# Altium schematic sheet Page H_B2B_A.SchDoc
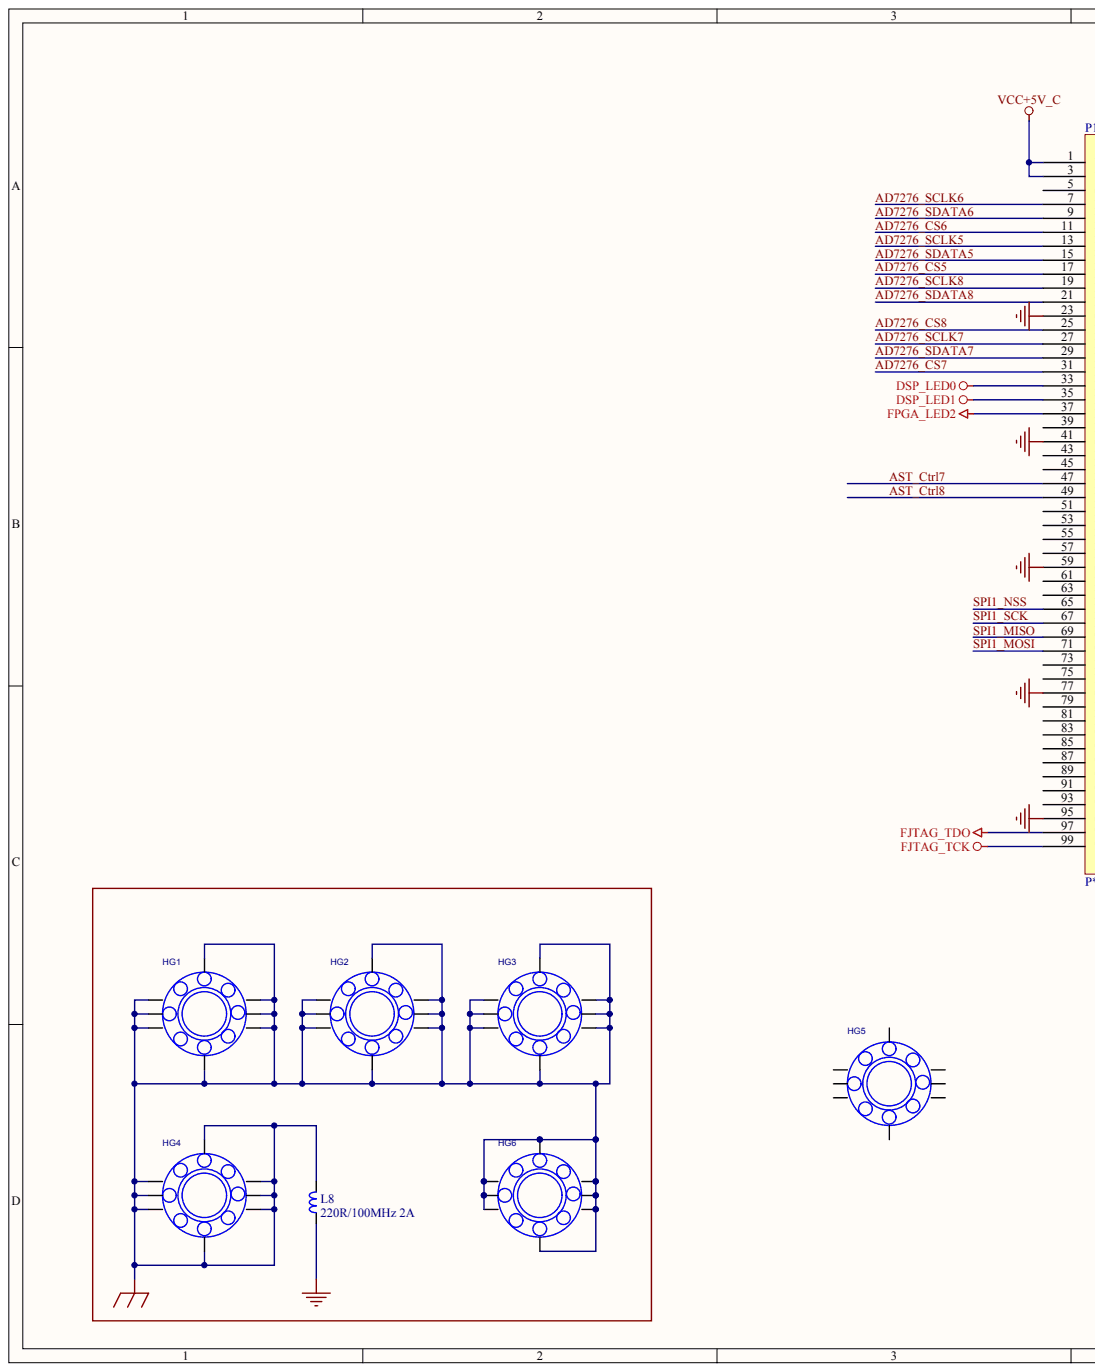
[diagram p0: Page H_B2B_A.SchDoc, left]
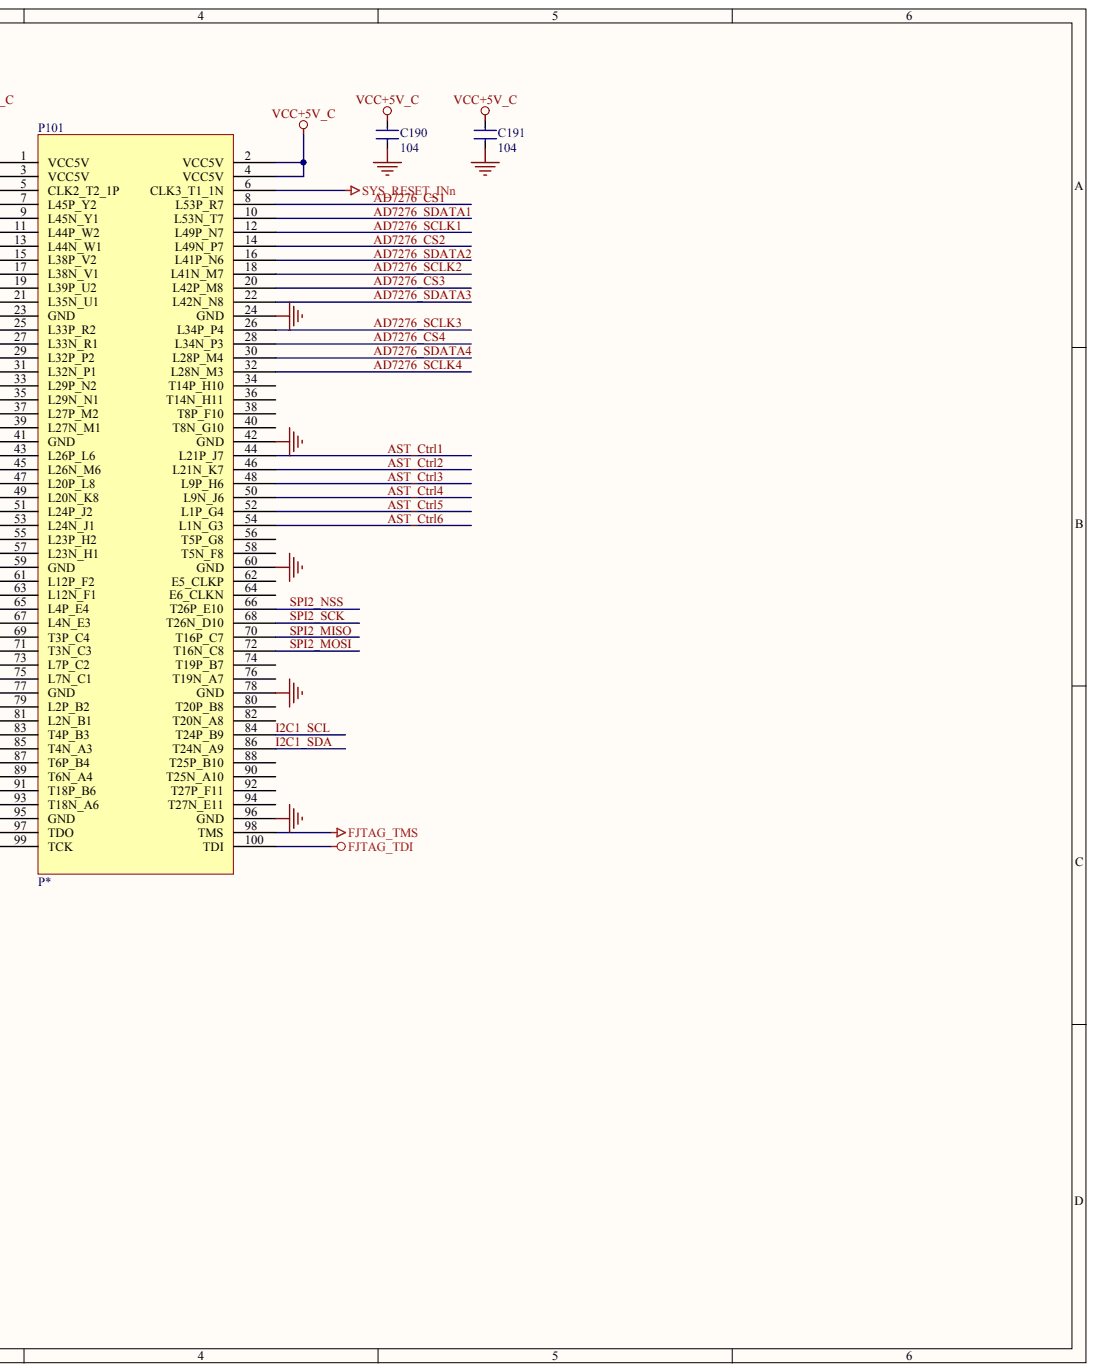
[diagram p1: Page H_B2B_A.SchDoc, right]

## Parts
C190  104
C191  104
HG1  MOUNTING_HOLE_NL  (value not drawn)
HG2  MOUNTING_HOLE_NL  (value not drawn)
HG3  MOUNTING_HOLE_NL  (value not drawn)
HG4  MOUNTING_HOLE_NL  (value not drawn)
HG5  MOUNTING_HOLE_NL  (value not drawn)
HG6  MOUNTING_HOLE_NL  (value not drawn)
L8  220R/100MHz 2A
P101  P*

## Nets
DSP_LED0: P101.33(L29P_N2)
DSP_LED1: P101.35(L29N_N1)
FJTAG_TCK: P101.99(TCK)
FJTAG_TDI: P101.100(TDI)
FJTAG_TDO: P101.97(TDO)
FJTAG_TMS: P101.98(TMS)
FPGA_LED2: P101.37(L27P_M2)
GND: C190.1 C191.1 L8.1 P101.23(GND) P101.24(GND) P101.41(GND) P101.42(GND) P101.59(GND) P101.60(GND) P101.77(GND) P101.78(GND) P101.95(GND) P101.96(GND)
GND_EARTH: HG1.1 HG1.2 HG1.3 HG1.4 HG1.5 HG1.6 HG1.7 HG1.8 HG2.1 HG2.2 HG2.3 HG2.4 HG2.5 HG2.6 HG2.7 HG2.8 HG3.1 HG3.2 HG3.3 HG3.4 HG3.5 HG3.6 HG3.7 HG3.8 HG4.1 HG4.2 HG4.3 HG4.4 HG4.5 HG4.6 HG4.7 HG4.8 HG6.1 HG6.2 HG6.3 HG6.4 HG6.5 HG6.6 HG6.7 HG6.8 L8.2
SYS_RESET_INN: P101.6(CLK3_T1_1N)
VCC+5V_C: C190.2 C191.2 P101.1(VCC5V) P101.2(VCC5V) P101.3(VCC5V) P101.4(VCC5V)
AD7276_CS1: P101.8(L53P_R7)
AD7276_CS2: P101.14(L49N_P7)
AD7276_CS3: P101.20(L42P_M8)
AD7276_CS4: P101.28(L34N_P3)
AD7276_CS5: P101.17(L38N_V1)
AD7276_CS6: P101.11(L44P_W2)
AD7276_CS7: P101.31(L32N_P1)
AD7276_CS8: P101.25(L33P_R2)
AD7276_SCLK1: P101.12(L49P_N7)
AD7276_SCLK2: P101.18(L41N_M7)
AD7276_SCLK3: P101.26(L34P_P4)
AD7276_SCLK4: P101.32(L28N_M3)
AD7276_SCLK5: P101.13(L44N_W1)
AD7276_SCLK6: P101.7(L45P_Y2)
AD7276_SCLK7: P101.27(L33N_R1)
AD7276_SCLK8: P101.19(L39P_U2)
AD7276_SDATA1: P101.10(L53N_T7)
AD7276_SDATA2: P101.16(L41P_N6)
AD7276_SDATA3: P101.22(L42N_N8)
AD7276_SDATA4: P101.30(L28P_M4)
AD7276_SDATA5: P101.15(L38P_V2)
AD7276_SDATA6: P101.9(L45N_Y1)
AD7276_SDATA7: P101.29(L32P_P2)
AD7276_SDATA8: P101.21(L35N_U1)
AST_CTRL1: P101.44(L21P_J7)
AST_CTRL2: P101.46(L21N_K7)
AST_CTRL3: P101.48(L9P_H6)
AST_CTRL4: P101.50(L9N_J6)
AST_CTRL5: P101.52(L1P_G4)
AST_CTRL6: P101.54(L1N_G3)
AST_CTRL7: P101.47(L20P_L8)
AST_CTRL8: P101.49(L20N_K8)
I2C1_SCL: P101.84(T24P_B9)
I2C1_SDA: P101.86(T24N_A9)
SPI1_MISO: P101.69(T3P_C4)
SPI1_MOSI: P101.71(T3N_C3)
SPI1_NSS: P101.65(L4P_E4)
SPI1_SCK: P101.67(L4N_E3)
SPI2_MISO: P101.70(T16P_C7)
SPI2_MOSI: P101.72(T16N_C8)
SPI2_NSS: P101.66(T26P_E10)
SPI2_SCK: P101.68(T26N_D10)

Not connected: HG5 1 2 3 4 5 6 7 8; P101 5(CLK2_T2_1P) 34(T14P_H10) 36(T14N_H11) 38(T8P_F10) 39(L27N_M1) 40(T8N_G10) 43(L26P_L6) 45(L26N_M6) 51(L24P_J2) 53(L24N_J1) 55(L23P_H2) 56(T5P_G8) 57(L23N_H1) 58(T5N_F8) 61(L12P_F2) 62(E5_CLKP) 63(L12N_F1) 64(E6_CLKN) 73(L7P_C2) 74(T19P_B7) 75(L7N_C1) 76(T19N_A7) 79(L2P_B2) 80(T20P_B8) 81(L2N_B1) 82(T20N_A8) 83(T4P_B3) 85(T4N_A3) 87(T6P_B4) 88(T25P_B10) 89(T6N_A4) 90(T25N_A10) 91(T18P_B6) 92(T27P_F11) 93(T18N_A6) 94(T27N_E11)

## Library data of the parts
HG1-HG6 = (no symbol name): Install: No; Remark: 孔
